# Altium schematic sheet Qi_chg.SchDoc(Qi_chg)
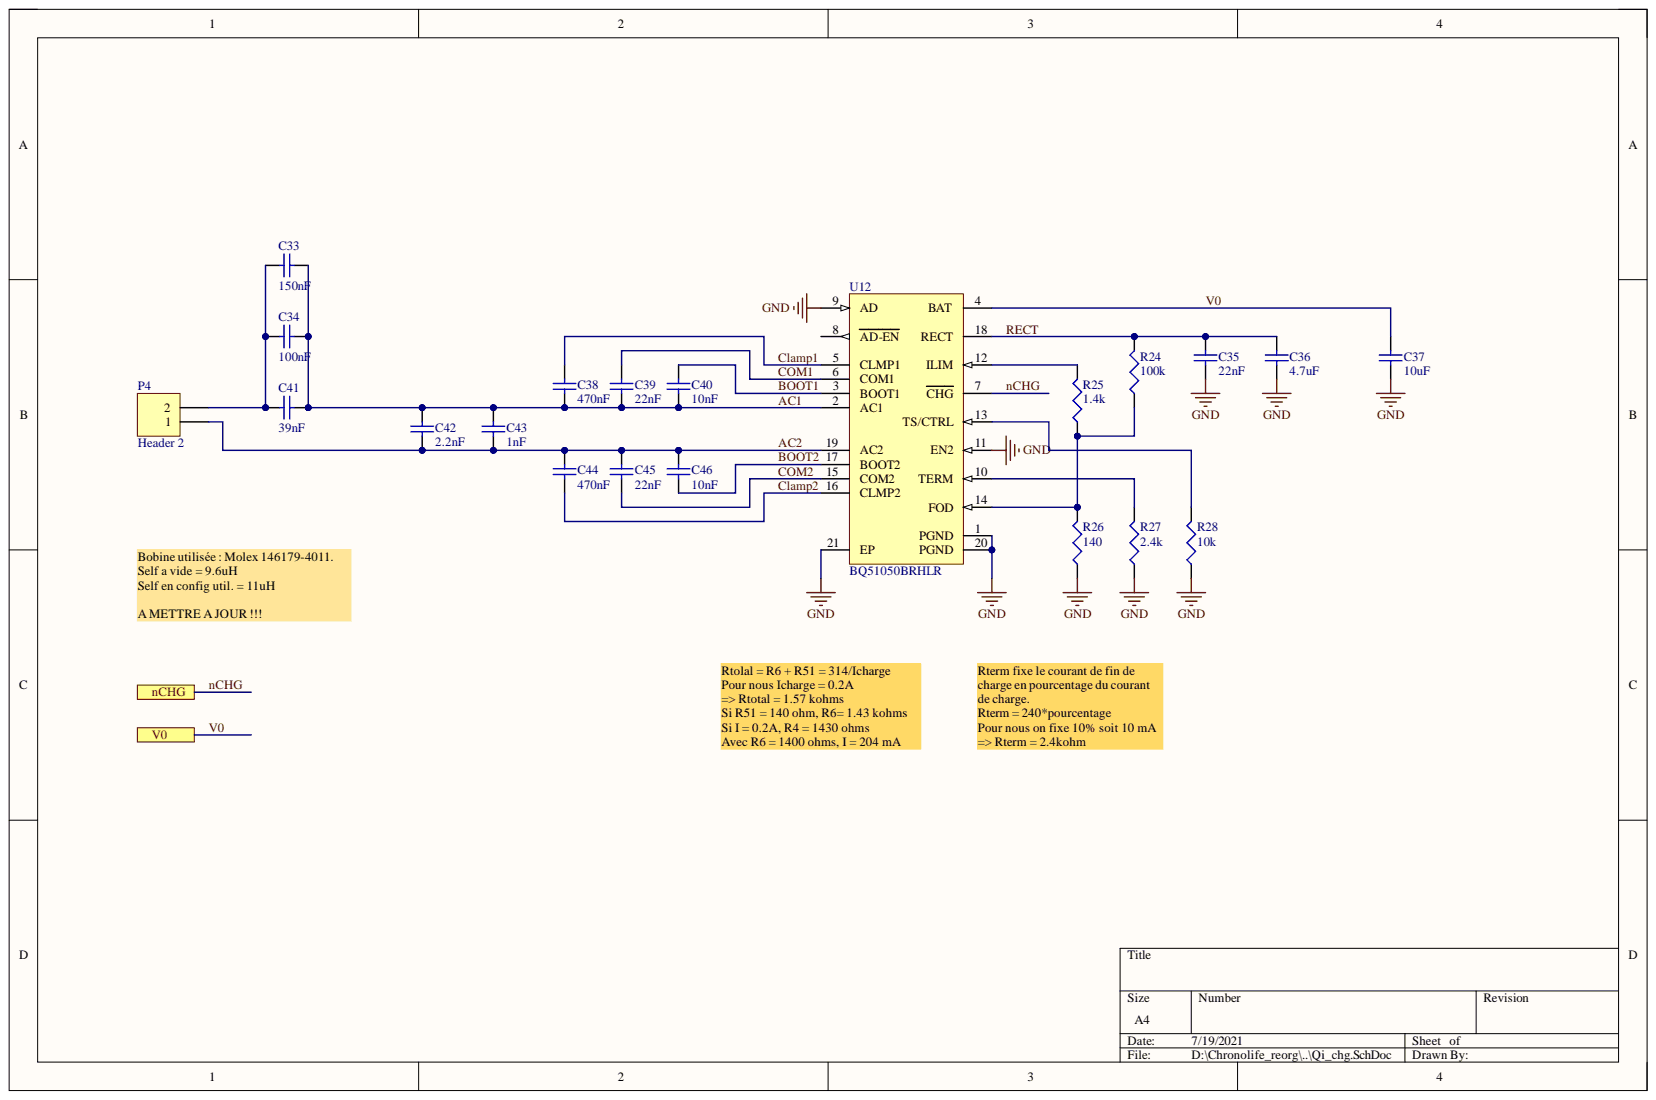
[diagram p0: Qi_chg.SchDoc]

## Parts
C33  150nF  [0603_GC]
C34  100nF  [0603_GC]
C35  22nF  [0402_GC]
C36  4.7uF  [0603_GC]
C37  10uF  [0603_GC]
C38  470nF  [0603_GC]
C39  22nF  [0402_GC]
C40  10nF  [0402_GC]
C41  39nF  [0603_GC]
C42  2.2nF  [0603_GC]
C43  1nF  [0603_GC]
C44  470nF  [0603_GC]
C45  22nF  [0402_GC]
C46  10nF  [0402_GC]
P4  Header 2  [2PAD_MIXTE]
R24  100k  [0402_GC]
R25  1.4k  [0402_GC]
R26  140  [0402_GC]
R27  2.4k  [0402_GC]
R28  10k  [0402_GC]
U12  BQ51050BRHLR  [BQ51050]

## Ports
nCHG, V0

## Nets
GND: C35.1 C36.1 C37.1 R26.1 R27.1 R28.1 U12.1(PGND) U12.9(AD) U12.11(EN2) U12.20(PGND) U12.21(EP)
AC1: C33.1 C34.1 C38.1 C39.1 C40.1 C41.1 C42.1 C43.1 U12.2(AC1)
AC2: C42.2 C43.2 C44.2 C45.2 C46.2 P4.1 U12.19(AC2)
BOOT1: C40.2 U12.3(BOOT1)
BOOT2: C46.1 U12.17(BOOT2)
Clamp1: C38.2 U12.5(CLMP1)
Clamp2: C44.1 U12.16(CLMP2)
COM1: C39.2 U12.6(COM1)
COM2: C45.1 U12.15(COM2)
nCHG: U12.7(CHG) ; port nCHG
RECT: C35.2 C36.2 R24.2 U12.18(RECT)
V0: C37.2 U12.4(BAT) ; port V0
NetC33_2: C33.2 C34.2 C41.2 P4.2
NetR24_1: R24.1 R25.1 R26.2 U12.14(FOD)
NetR25_2: R25.2 U12.12(ILIM)
NetR27_2: R27.2 U12.10(TERM)
NetR28_2: R28.2 U12.13(TS/CTRL)

Not connected: U12 8(AD-EN)

## Library data of the parts
C33-C36 C38-C46 = Cap: Capacitor; Component Kind: Standard; LatestRevisionDate: 17-Jul-2002; LatestRevisionNote: Re-released for DXP Platform.; Library Name: Miscellaneous Devices.IntLib; PackageDescription: Radial Cap, Thru-Hole; 2 Leads; 0.3 in Pin Spacing; PackageReference: RAD-0.3; Published: 8-Jun-2000; Signal Integrity: Cap; Simulation: CAP; Publisher: Altium Limited
  C33: Value: 150nF
  C34: Value: 100nF
  C35 C39 C45: Value: 22nF
  C36: Value: 4.7uF
  C38 C44: Value: 470nF
  C40 C46: Value: 10nF
  C41: Value: 39nF
  C42: Value: 2.2nF
  C43: Value: 1nF
C37 = Cap: Capacitor; Value: 4.7uF; Component Kind: Standard; LatestRevisionDate: 17-Jul-2002; LatestRevisionNote: Re-released for DXP Platform.; Library Name: Miscellaneous Devices.IntLib; PackageDescription: Radial Cap, Thru-Hole; 2 Leads; 0.3 in Pin Spacing; PackageReference: RAD-0.3; Published: 8-Jun-2000; Signal Integrity: Cap; Simulation: CAP; Publisher: Altium Limited
P4 = Header 2: Header, 2-Pin; Component Kind: Standard; LatestRevisionDate: 17-Jul-2002; LatestRevisionNote: Re-released for DXP Platform.; Library Name: Miscellaneous Connectors.IntLib; Signal Integrity: Connector; Publisher: Altium Limited
R24-R28 = Res3: Resistor; Component Kind: Standard; LatestRevisionDate: 29-May-2009; LatestRevisionNote: IPC-7351 Footprint Added.; Library Name: Miscellaneous Devices.IntLib; PackageDescription: Chip Resistor; PackageReference: J1-0603; Published: 8-Jun-2000; Signal Integrity: Res; Simulation: RESISTOR; Publisher: Altium Limited
  R24: Value: 100k
  R25: Value: 1.4k
  R26: Value: 140
  R27: Value: 2.4k
  R28: Value: 10k
U12 = BQ51050BRHLR: Qi (WPC) Compliant Highly Integrated Secondary-Side Direct Lithium Ion Charger, 4 V Min Input, 20-pin VQFN (RHL), Green (RoHS & no Sb/Br); Charge Current(Max)(A): 1.5; Eco-Plan: TRUE; Vin(Min)(V): 4; Component Kind: Standard; ComponentLink1Description: Manufacturer URL; ComponentLink1URL: http://www.ti.com/; ComponentLink2Description: Datasheet; ComponentLink2URL: http://www.ti.com/lit/ds/symlink/bq51050b.pdf; ComponentLink3Description: Package Specification; ComponentLink3URL: http://www.ti.com/lit/ds/symlink/bq51051b.pdf; DatasheetVersion: SLUSB42D; Library Name: Texas_Instruments_BQ51050BRHLR.SchLib; Mounting Technology: Surface Mount; PackageDescription: 20-Pin Plastic Flat Pack, Body 4.5 x 3.5 mm, Pitch 0.5 mm; PackageVersion: 4205346-3/J, 12/2011; PartNumber: BQ51050BRHLR
